# Altium schematic sheet SENS&AO.SchDoc(SENS&AO)
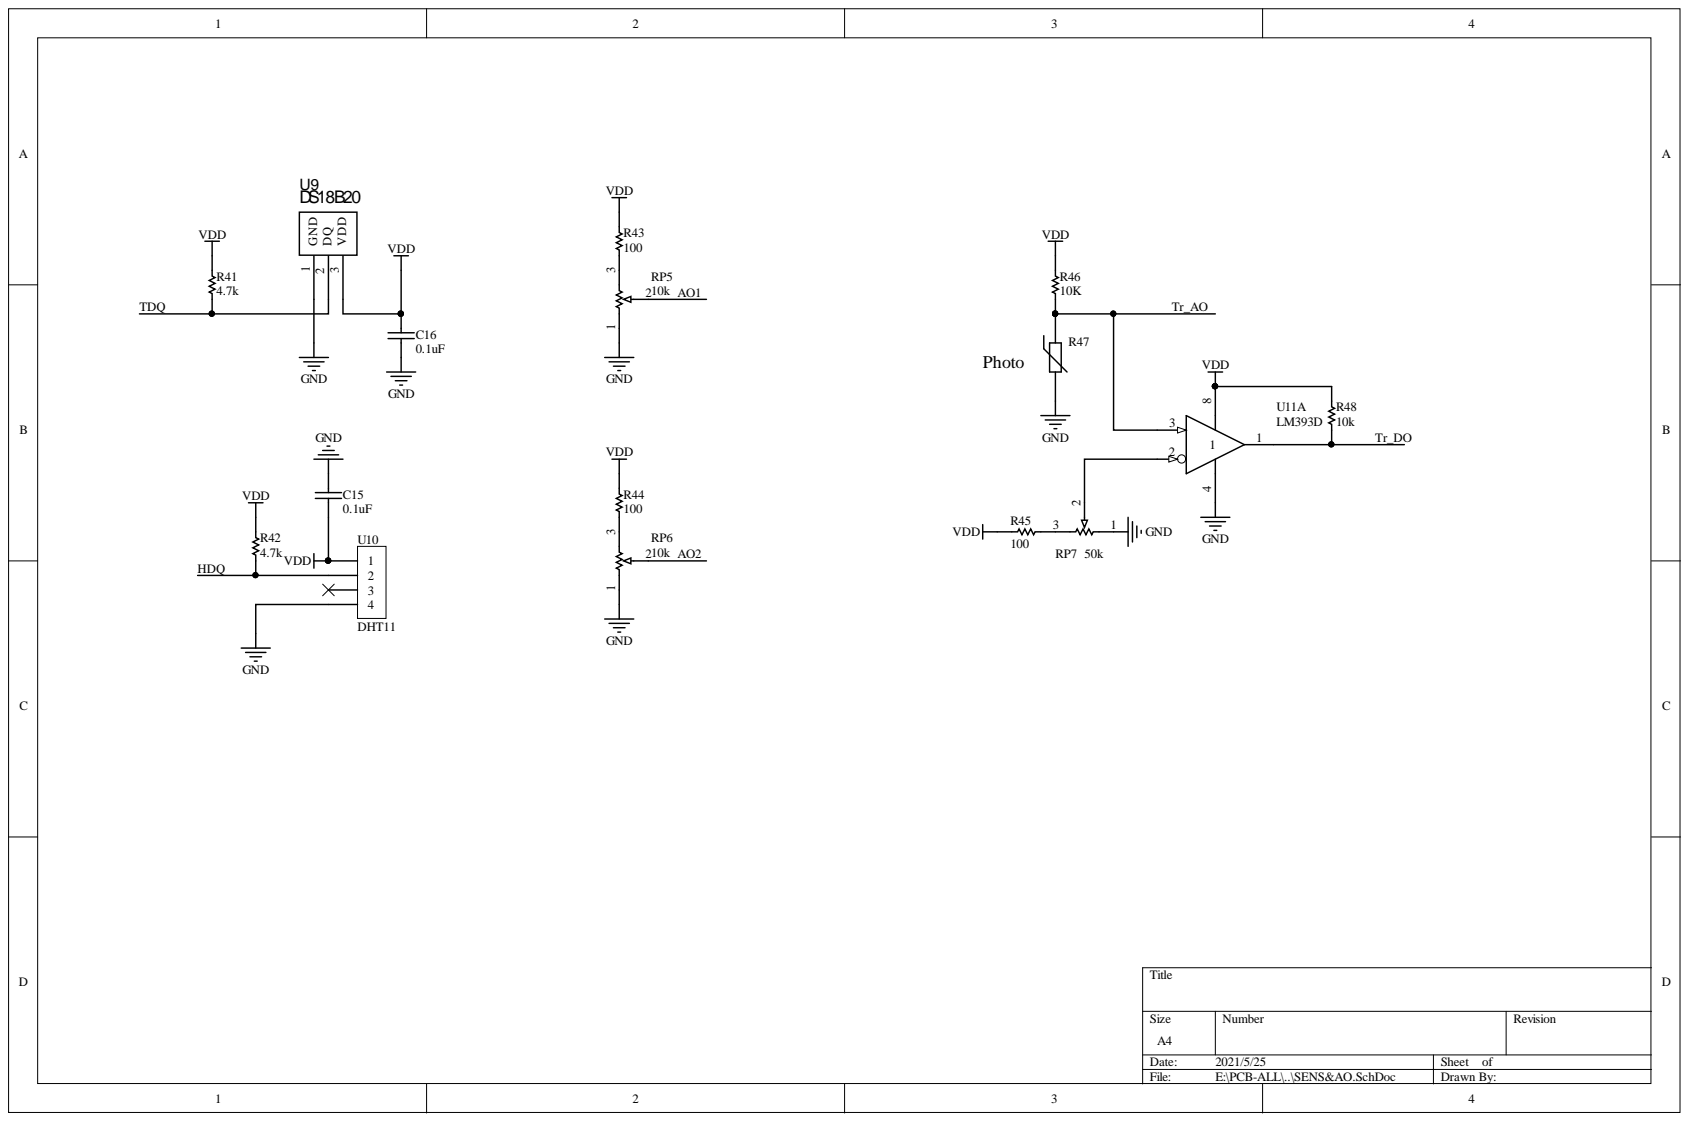
[diagram p0: SENS&AO.SchDoc]

## Parts
C15  0.1uF  [C0805]
C16  0.1uF  [C0805]
R41  4.7k  [R0805]
R42  4.7k  [R0805]
R43  100  [R0805]
R44  100  [R0805]
R45  100  [R0805]
R46  10K  [R0805]
R47  Res Varistor  [R-PHOTO]  (value not drawn)
R48  10k  [R0805]
RP5  10k  [RES-3296W]
RP6  10k  [RES-3296W]
RP7  50k  [RES-3296W]
U9  DS18B20  [DS18B20]
U10  DHT11  [DHT11_Cal]
U11  LM393D  [SO-8]  (part A)

## Nets
GND: C15.1 C16.2 R47.2 RP5.1 RP6.1 RP7.1 U9.1 U10.4 U11.4
VDD: C15.2 C16.1 R41.1 R42.1 R43.2 R44.2 R45.2 R46.1 R48.2 U9.3 U10.1 U11.8
AO1: RP5.2
AO2: RP6.2
HDQ: R42.2 U10.2
TDQ: R41.2 U9.2
TR_AO: R46.2 R47.1 U11.3
TR_DO: R48.1 U11.1
NetR43_1: R43.1 RP5.3
NetR44_1: R44.1 RP6.3
NetR45_1: R45.1 RP7.3
NetRP7_2: RP7.2 U11.2

Not connected: U10 3

## Library data of the parts
C15 C16 = CAP: Capacitor; 中文名称: 贴片电容; Component Kind: Standard; Library Name: 2014.SchLib
R41-R46 R48 = Res1: Resistor; Value: 1K; Component Kind: Standard; Library Name: 2014.SchLib; Published: 8-Jun-2000; Revision: July-2002: Re-released for DXP Platform.; Signal Integrity: Res; Simulation: RESISTOR; Publisher: Altium Limited
R47 = Res Varistor: Varistor (Voltage-Sensitive Resistor); Code_IPC: RESC2012; Component Kind: Standard; LatestRevisionDate: 29-May-2009; LatestRevisionNote: IPC-7351 Footprint Added.; Library Name: Miscellaneous Devices.IntLib; PackageDescription: Chip Resistor 0805 Case Size; PackageReference: 6-0805; PackageVersion: Mar-2003; Published: 24-Mar-1999; Publisher: Altium Limited
RP5-RP7 = RPot: Potentiometer; Set Position: 0.5; Sim Note: Enter the Resistance value and the set point into parameter fields.; Value: 1K; Component Kind: Standard; LatestRevisionDate: 17-Jul-2002; LatestRevisionNote: Re-released for DXP Platform.; Library Name: Miscellaneous Devices.IntLib; PackageReference: VR5; Published: 8-Jun-2000; Simulation: POT; Publisher: Altium Limited
U10 = Header 4: Header, 5-Pin; Component Kind: Standard; Library Name: 2014.SchLib; Revision: 17-Jul-2002: Re-released for DXP Platform.; Publisher: Altium Limited
U11 = LM393D: Dual Differential Comparator; Code_IPC: SOIC127P600-8; Component Kind: Standard; ComponentLink1Description: Manufacturer Link; ComponentLink1URL: http://www.ti.com/; ComponentLink2Description: Datasheet; ComponentLink2URL: http://www-s.ti.com/sc/ds/lm193.pdf; DatasheetVersion: Nov-1988; LatestRevisionDate: 13-Apr-2006; LatestRevisionNote: IPC-7351 Footprint Added.; Library Name: TI Logic Comparator.IntLib; PackageDescription: 8-Pin Small Outline Integrated Circuit 1.27 mm Pitch; PackageReference: D008; PackageVersion: Jan-1998; Published: 15-Apr-2002; Publisher: Altium Limited
U9 = DS18B20: Component Kind: Standard
